# Altium schematic sheet Atmega328P.SchDoc(Atmega328P)
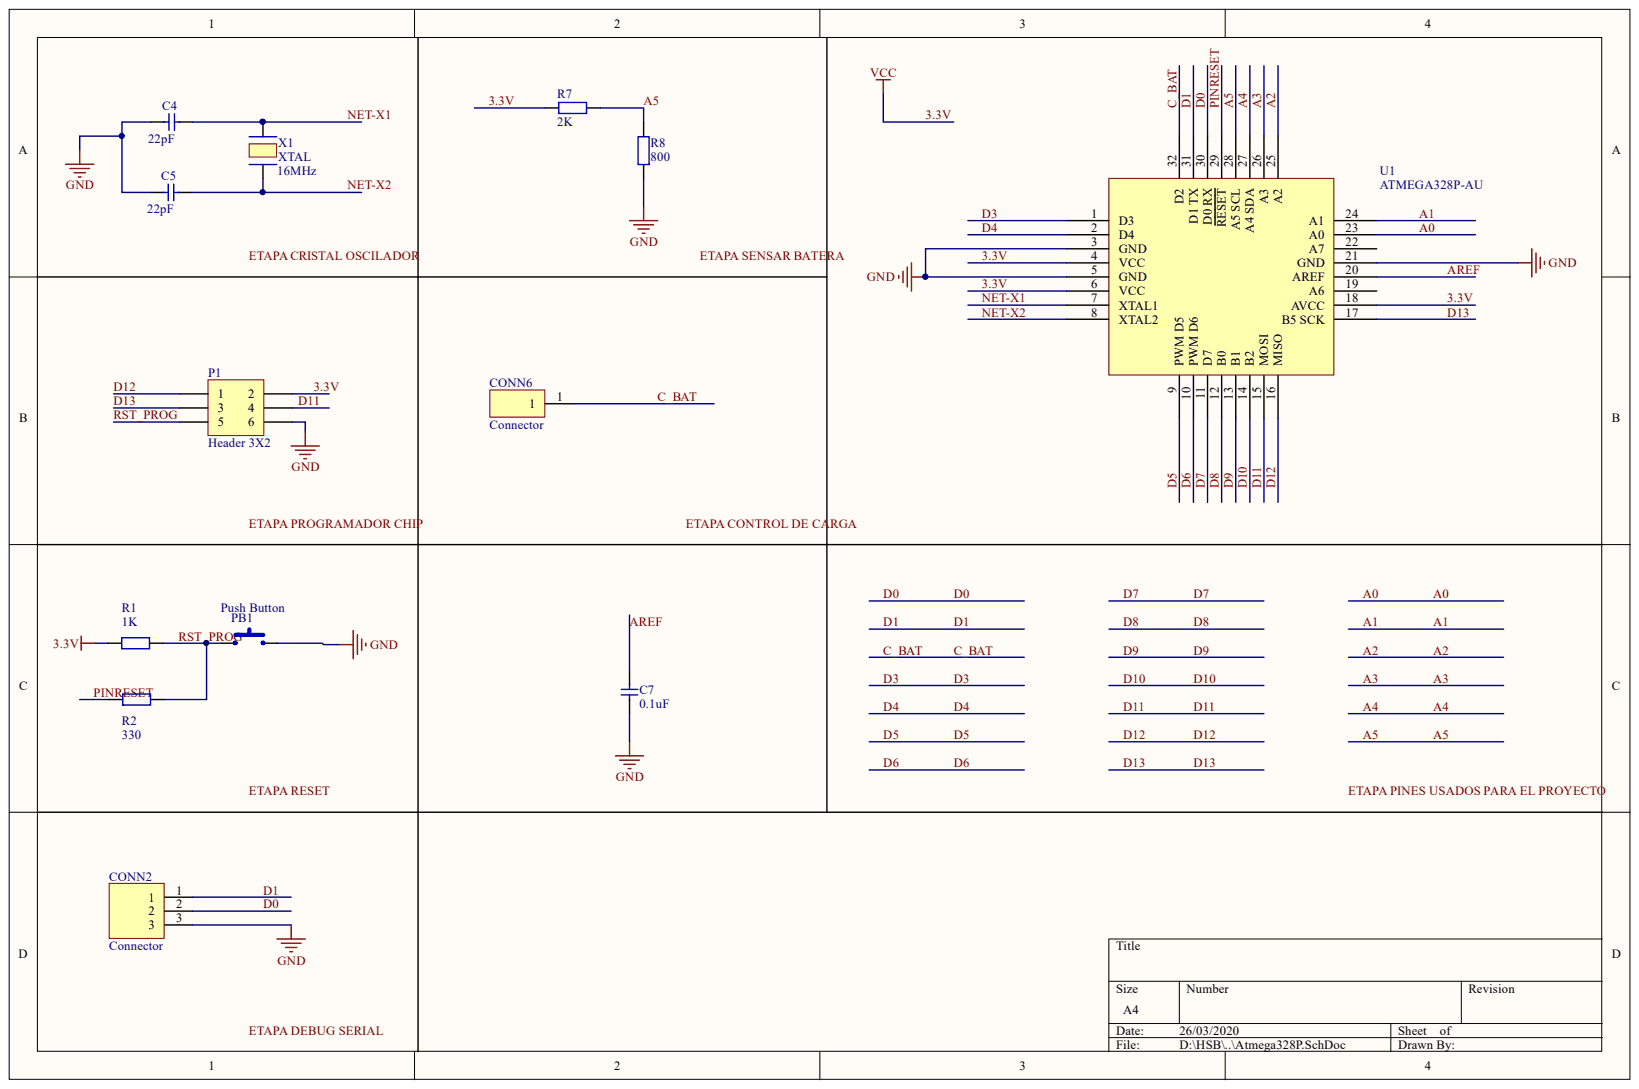
[diagram p0: Atmega328P.SchDoc]

## Parts
C4  value 22pF  [C1206-1.25]  (hidden comment: C1)
C5  value 22pF  [C1206-1.25]  (hidden comment: C2)
C7  value 0.1uF  [C1206-1.25]  (hidden comment: C2)
CONN2  Connector  [1x3x2.54 Pin Header TH V]
CONN6  Connector  [1x1x2.54 Pin Header TH V]
P1  Header 3X2  [HDR2X3]
PB1  Push Button  [Tack Switch 6x6 1mm TH]
R1  R  value 1K  [R1206-0.55]
R2  R  value 330  [R1206-0.55]
R7  R  value 2K  [R1206-0.55]
R8  R  value 800  [R1206-0.55]
U1  ATMEGA328P-AU  [TQFP80P900X900X120-32N]
X1  XTAL  value 16MHz  [2-SMD]

## Nets
GND: C4.1 C5.1 C7.1 CONN2.3 P1.6 PB1.2 R8.1 U1.3(GND) U1.5(GND) U1.21(GND)
3.3V: P1.2 R1.1 R7.2 U1.4(VCC) U1.6(VCC) U1.18(AVCC)
A0: U1.23(A0)
A1: U1.24(A1)
A2: U1.25(A2)
A3: U1.26(A3)
A4: U1.27(A4_SDA)
A5: R7.1 R8.2 U1.28(A5_SCL)
AREF: C7.2 U1.20(AREF)
C_BAT: CONN6.1 U1.32(D2)
D0: CONN2.2 U1.30(D0_RX)
D1: CONN2.1 U1.31(D1_TX)
D3: U1.1(D3)
D4: U1.2(D4)
D5: U1.9(PWM_D5)
D6: U1.10(PWM_D6)
D7: U1.11(D7)
D8: U1.12(B0)
D9: U1.13(B1)
D10: U1.14(B2)
D11: P1.4 U1.15(MOSI)
D12: P1.1 U1.16(MISO)
D13: P1.3 U1.17(B5_SCK)
NET-X1: C4.2 U1.7(XTAL1) X1.2
NET-X2: C5.2 U1.8(XTAL2) X1.1
PINRESET: R2.1 U1.29(RESET)
RST_PROG: P1.5 PB1.1 R1.2 R2.2
ETAPA CONTROL DE CARGA:
ETAPA CRISTAL OSCILADOR:
ETAPA DEBUG SERIAL:
ETAPA PINES USADOS PARA EL PROYECTO:
ETAPA PROGRAMADOR CHIP:
ETAPA RESET:
ETAPA SENSAR BATERA:

Not connected: U1 19(A6) 22(A7)

## Library data of the parts
C4 C5 C7 = C: Capacitor, generic; Component Kind: Standard; Library Name: IFS_Altium.IntLib
CONN2 = Header 1x3: Component Kind: Standard; Library Name: IFS_Altium.IntLib
CONN6 = Header 1x1: Component Kind: Standard; Library Name: IFS_Altium.IntLib
P1 = Header 3X2: Header, 3-Pin, Dual row; Component Kind: Standard; LatestRevisionDate: 17-Jul-2002; LatestRevisionNote: Re-released for DXP Platform.; Library Name: Miscellaneous Connectors.IntLib; Signal Integrity: Connector; Publisher: Altium Limited
R1 R2 R7 R8 = R: Resistor, generic; Component Kind: Standard; Library Name: IFS_Altium.IntLib
U1 = ATMEGA328P-AU: Microchip Technology ATMEGA328P-AN, 8bit AVR Microcontroller, 20MHz, 32 kB Flash, 32-Pin TQFP; Company: Gueni; Component Kind: Standard; Datasheet URL: https://docs-emea.rs-online.com/webdocs/15b8/0900766b815b8e7b.pdf; Date: 20 October 2018; RS Stock No: 131-0271; Created By: Mohamed Gueni; Library Name: Atmel_mico.IntLib
X1 = XTAL: Crystal, Generic; Component Kind: Standard; Library Name: IFS_Altium.IntLib
PB1 = Push Button: Component Kind: Standard; Description: Push Button; Library Name: IFS_Altium.IntLib
